# Altium schematic sheet schematic.SchDoc(schematic)
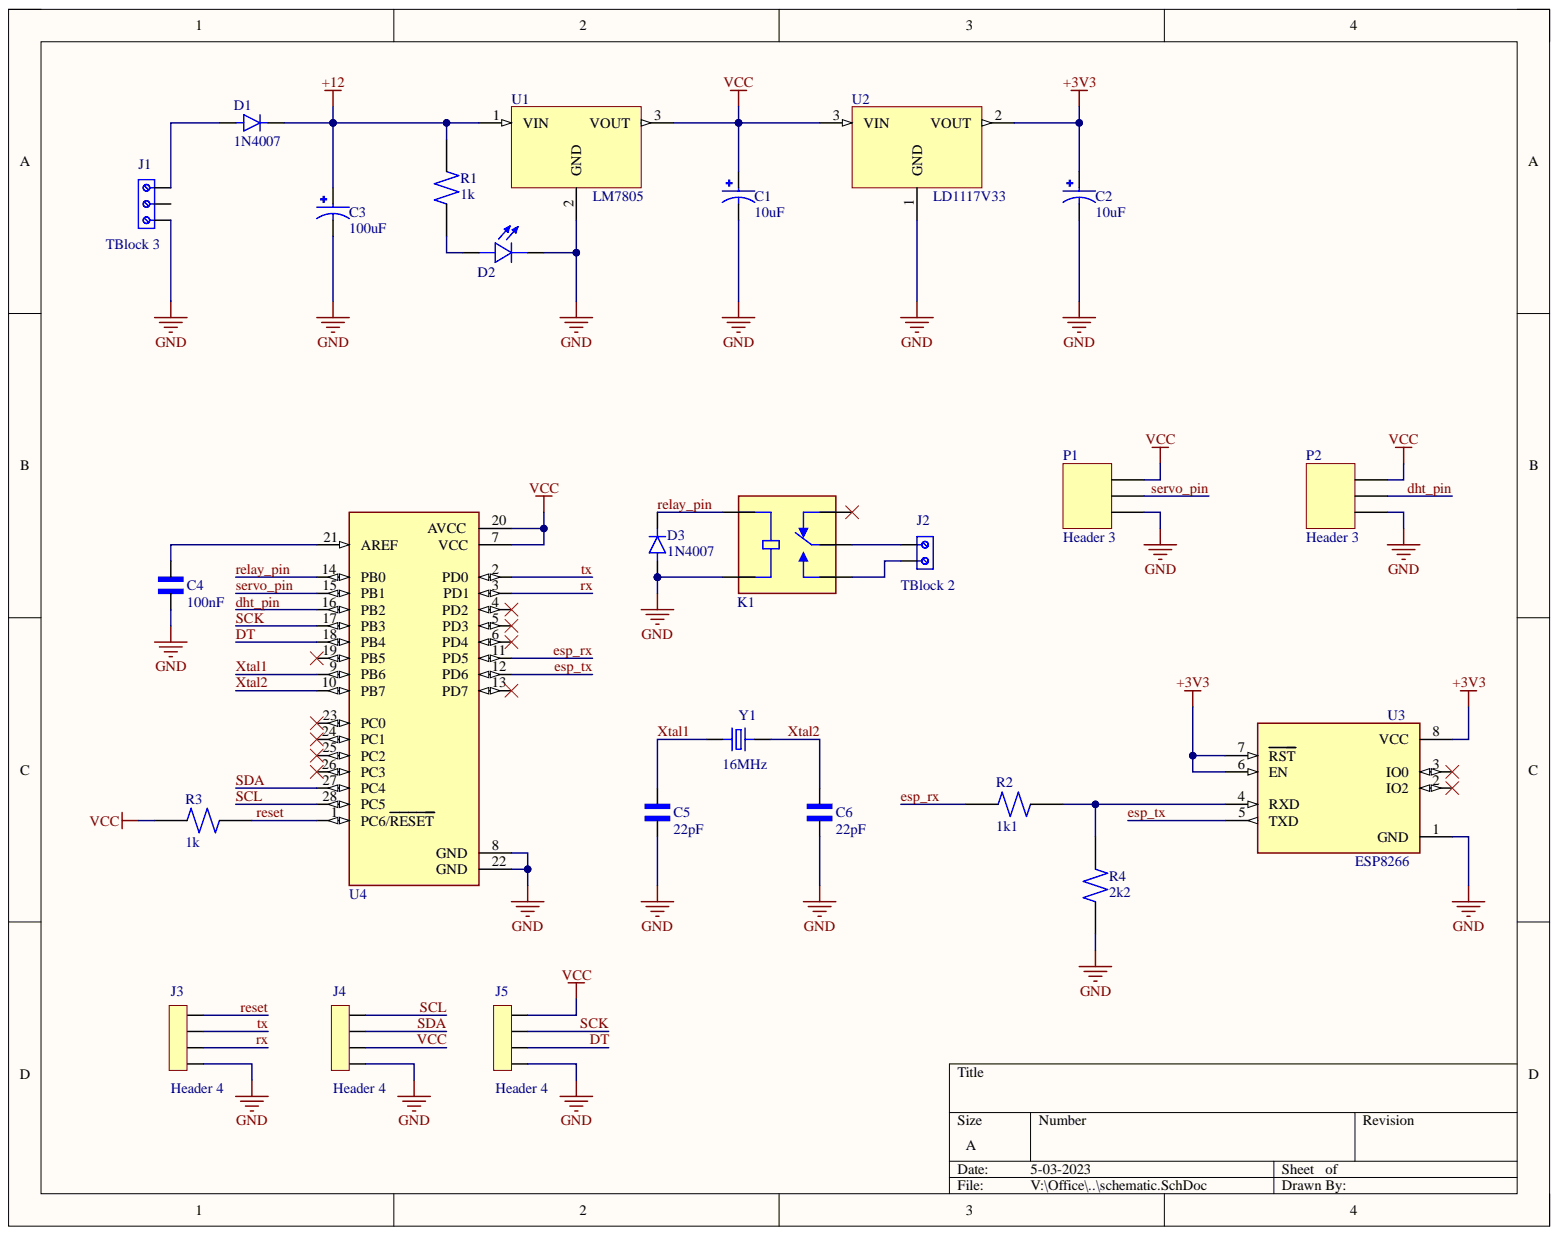
[diagram p0: schematic.SchDoc]

## Parts
C1  10uF  [CAPPRD500W50D500H1100]
C2  10uF  [CAPPRD500W50D500H1100]
C3  100uF  [CAPPRD500W50D500H1100]
C4  100nF  [CAPRB500W50L450T300H550]
C5  22pF  [CAPRB500W50L450T300H550]
C6  22pF  [CAPRB500W50L450T300H550]
D1  1N4007  [DIOAD1060W80L520D270]
D2  L08R5000Q1  [LEDRD254W57D500H1070]  (value not drawn)
D3  1N4007  [DIOAD1060W80L520D270]
J1  TBlock 3  [691214110003]
J2  TBlock 2  [691111710002]
J3  Header 4  [SULLINS_PPTC041LFBN-RC]
J4  Header 4  [SULLINS_PPTC041LFBN-RC]
J5  Header 4  [SULLINS_PPTC041LFBN-RC]
K1  JQC-3F-1C-24VDC  [RELAY_JQC-3F-1C-24VDC]  (value not drawn)
P1  Header 3  [HDR1X3]
P2  Header 3  [HDR1X3]
R1  1k  [RESAD1100W55L680D260]
R2  1k1  [RESAD1100W55L680D260]
R3  1k  [RESAD1100W55L680D260]
R4  2k2  [RESAD1100W55L680D260]
U1  LM7805  [TO255P1020X450X1968-3]
U2  LD1117V33  [TO255P1020X450X1968-3]
U3  ESP8266  [XCVR_ESP8266-01/ESP-01]
U4  ATMEGA328P-PU  [DIP794W46P254L2967H457Q28B]  (value not drawn)
Y1  16MHz  [XTAL_ECS-160-20-4X]

## Nets
+3V3: C2.P U2.2 U3.6(EN) U3.7(RST) U3.8(VCC)
+12: C3.P D1.C R1.2 U1.1
GND: C1.N C2.N C3.N C4.1 C5.1 C6.1 D2.C D3.A J1.1 J3.4 J4.4 J5.4 K1.COIL2 P1.1 P2.1 R4.1 U1.2 U2.1 U3.1(GND) U4.8(GND) U4.22(GND)
dht_pin: P2.2 U4.16(PB2)
DT: J5.3 U4.18(PB4)
esp_rx: R2.2 U4.11(PD5)
esp_tx: U3.5(TXD) U4.12(PD6)
relay_pin: D3.C K1.COIL1 U4.14(PB0)
reset: J3.1 R3.1 U4.1(PC6/RESET)
rx: J3.3 U4.3(PD1)
SCK: J5.2 U4.17(PB3)
SCL: J4.1 U4.28(PC5)
SDA: J4.2 U4.27(PC4)
servo_pin: P1.2 U4.15(PB1)
tx: J3.2 U4.2(PD0)
VCC: C1.P J4.3 J5.1 P1.3 P2.3 R3.2 U1.3 U2.3 U4.7(VCC) U4.20(AVCC)
Xtal1: C5.2 U4.9(PB6) Y1.1
Xtal2: C6.2 U4.10(PB7) Y1.2
NetC4_2: C4.2 U4.21(AREF)
NetD1_A: D1.A J1.3
NetD2_A: D2.A R1.1
NetJ2_1: J2.1 K1.COM
NetJ2_2: J2.2 K1.NO
NetR2_1: R2.1 R4.2 U3.4(RXD)

Not connected: J1 2; K1 NC; U3 2(IO2) 3(IO0); U4 4(PD2) 5(PD3) 6(PD4) 13(PD7) 19(PB5) 23(PC0) 24(PC1) 25(PC2) 26(PC3)

## Library data of the parts
C1-C3 = Electrolytic capacitor: FS-A; MF: {'manufacturer': u'Panasonic'}; MP: EEUFS0J221B; Availability: Unavailable; Component Kind: Standard; Package: None; Price: None; Library Name: Installed library.IntLib
C4-C6 = Ceramic capacitor: 2200 pF Â±10% 250V Ceramic Capacitor X7R Radial; MF: TDK; MP: FG24X7R2E222KNT06; Check_prices: https://www.snapeda.com/parts/FG24X7R2E222KNT06/TDK/view-part/?ref=eda; Component Kind: Standard; Digi-Key_Part_Number: 445-173386-1-ND; Package: Radial TDK; Purchase-URL: https://pricing.snapeda.com/search/part/FG24X7R2E222KNT06/?ref=eda; SnapEDA_Link: https://www.snapeda.com/parts/FG24X7R2E222KNT06/TDK/view-part/?ref=snap; Library Name: Installed library.IntLib
D1 D3 = 1N4007: Diode Switching 1KV 1A 2-Pin DO-41; Availability: Unavailable; Component Kind: Standard; Library Name: Components.IntLib; MP: 1N4007; Package: None; Price: None
D2 = LED: LED, Orange, Through Hole, T-1 3/4 (5mm), 30 mA, 2.1 V, 635 nm; MF: {'manufacturer': u'LED Technology'}; Availability: Unavailable; Component Kind: Standard; MP: L08R5000Q1; Package: None; Price: None; Library Name: Installed library.IntLib
J1 = Terminal blocks - 3Pins (Small): 3 Position Wire to Board Terminal Block Horizontal with Board 0.138 (3.50mm) Through Hole; MF: Wurth Elektronik; Availability: In Stock; Check_prices: https://www.snapeda.com/parts/691214110003/Wurth+Elektronik/view-part/?ref=eda; Component Kind: Standard; MP: 691214110003; Package: None; Price: None; Purchase-URL: https://pricing.snapeda.com/search/part/691214110003/?ref=eda; SnapEDA_Link: https://www.snapeda.com/parts/691214110003/Wurth+Elektronik/view-part/?ref=snap; Library Name: Installed library.IntLib
J2 = Terminal Block - 2Pins: 2 Position Wire to Board Terminal Block Horizontal with Board 0.197 _5.00mm_ Through Hole; MF: Wurth Electronics; Availability: Unavailable; Component Kind: Standard; MP: 691111710002; Package: None; Price: None; SnapEDA_Link: https://www.snapeda.com/parts/691111710002/Wurth%20Electronics%20Inc./view-part/1195092/?ref=snap; Library Name: Installed library.IntLib
J3-J5 = Female header - 4Pins: 4 Position Header Connector Through Hole; MF: Sullins Connector; MP: PPTC041LFBN-RC; Availability: Warning; Check_prices: https://www.snapeda.com/parts/PPTC041LFBN-RC/Sullins%20Connector%20Solutions/view-part/285917/?ref=eda; Component Kind: Standard; Package: None; Price: None; Purchase-URL: https://pricing.snapeda.com/search/part/PPTC041LFBN-RC/?ref=eda; SnapEDA_Link: https://www.snapeda.com/parts/PPTC041LFBN-RC/Sullins%20Connector%20Solutions/view-part/285917/?ref=snap; Library Name: Installed library.IntLib
K1 = Relay (Standard): Miniature PCB Relay 24VDC, 10A 250VAC, 15A 125VAC, 10A 250VAC; Availability: Unavailable; Component Kind: Standard; MP: JQC-3F-1C-24VDC; Package: None; Price: None; Library Name: Installed library.IntLib
P1 P2 = Header 3: Header, 3-Pin; Component Kind: Standard; LatestRevisionDate: 17-Jul-2002; LatestRevisionNote: Re-released for DXP Platform.; Library Name: Miscellaneous Connectors.IntLib; Signal Integrity: Connector; Publisher: Altium Limited
R1-R4 = Resistor (0.25W): (MFR-25FBF52-10K) 10,2 kOhm bei 1% 0,25W, 1/4W Durchbohrlochwiderstand Axial Metal Film; MF: Yageo; Component Kind: Standard; Digi-Key_Part_Number: 10.2KXBK-ND; MP: MFR-25FBF52-10K; Package: Axial Yageo; Purchase-URL: https://pricing.snapeda.com/search/part/MFR-25FBF52-10K/?ref=eda; SnapEDA_Link: https://www.snapeda.com/parts/MFR-25FBF52-10K/Yageo/view-part/424333/?ref=snap; Library Name: Installed library.IntLib
U1 = LM78__: MP: LM7805CT/NOPB; Availability: Unavailable; Component Kind: Standard; Library Name: Voltage regulator.IntLib; Package: None; Price: None
U3 = ESP8266-01 Module: MakerFocus 4pcs ESP8266 ESP-01 Serial Wireless WiFi Transceiver Receiver Module 1MB SPI Flash DC3.0-3.6V Internet of Things WiFi Module Board Compatible with Ar duino; MP: ESP8266-01/ESP-01; Availability: Unavailable; Component Kind: Standard; Package: None; Price: None; Library Name: Installed library.IntLib
U4 = ATMEGA328P-PU: ATmega Series 20 MHz 32 KB Flash 2 KB SRAM 8-Bit Microcontroller - DIP-28; Availability: Unavailable; Component Kind: Standard; MP: ATMEGA328P-PU; Package: None; Price: None; Library Name: Installed library.IntLib
Y1 = Crystal oscilator: CRYSTAL T/H; MF: {'manufacturer': u'ECS Inc.'}; MP: ECS-160-S-4; Availability: Unavailable; Component Kind: Standard; Package: None; Price: None; Library Name: Installed library.IntLib
U2 = LD1117V33: Availability: Unavailable; Component Kind: Standard; Library Name: Voltage regulator.IntLib; MP: LD1117V33; Package: None; Price: None
